# Altium schematic sheet Mechanical.SchDoc(Mechanical)
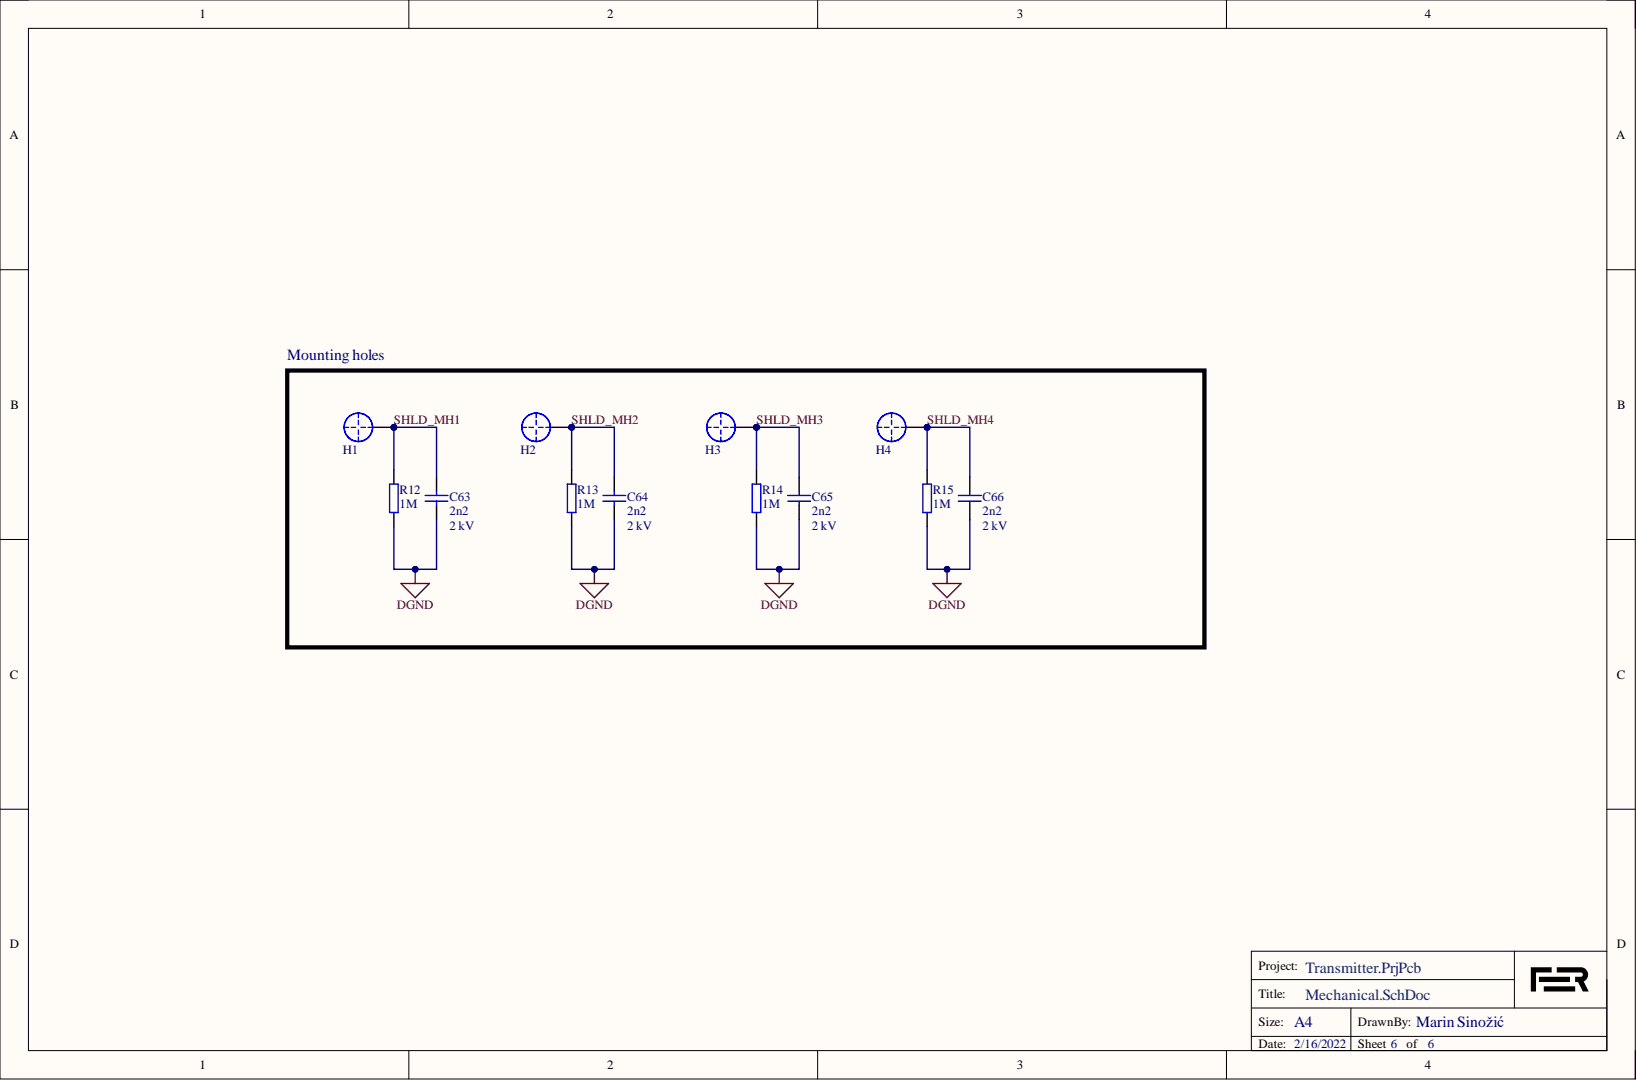
[diagram p0: Mechanical.SchDoc]

## Parts
C63  2n2  Voltage Rating: 2 kV  [0603]
C64  2n2  Voltage Rating: 2 kV  [0603]
C65  2n2  Voltage Rating: 2 kV  [0603]
C66  2n2  Voltage Rating: 2 kV  [0603]
H1  Hole_Mount  [HoleMount]  (value not drawn)  (no BOM)
H2  Hole_Mount  [HoleMount]  (value not drawn)  (no BOM)
H3  Hole_Mount  [HoleMount]  (value not drawn)  (no BOM)
H4  Hole_Mount  [HoleMount]  (value not drawn)  (no BOM)
R12  1M  [0603]
R13  1M  [0603]
R14  1M  [0603]
R15  1M  [0603]

## Nets
DGND: C63.1 C64.1 C65.1 C66.1 R12.1 R13.1 R14.1 R15.1
SHLD_MH1: C63.2 H1.1 R12.2
SHLD_MH2: C64.2 H2.1 R13.2
SHLD_MH3: C65.2 H3.1 R14.2
SHLD_MH4: C66.2 H4.1 R15.2

## Library data of the parts
C63-C66 = C_1206_2.2nF_2kV_X7R_AEC-Q200: CAP CER 0603 2.2NF 16V X7R 10%; Manufacturer: KEMET; Manufacturer Part Number: 399-C0603C222K4RECAUTOCT-ND; Capacitor Case Style: 1206 [3216 Metric]; Database Table Name: Capacitors-Block; RA_SN: C1206-0035; Capacitance Tolerance: ± 10 %; Component Kind: Standard; ComponentLink1Description: Datasheet; ComponentLink1URL: https://hr.mouser.com/datasheet/2/212/KEM_C1076_X7R_HV_AUTO_SMD-1093301.pdf; Dielectric Characteristic: X7R; Library Name: RA.DbLib; Operating Temperature Max: 125 °C; Part Number: C_1206_2.2nF_2kV_X7R_AEC-Q200; Price: 0.16; Qualification: AEC-Q200; Supplier 1: Digi-Key; Supplier 1 ERP Number: 01162; Supplier Part Number 1: 80-C1206C222KGRAUTO; Value: 2n2
H1-H4 = Hole_Mount_M3_Alt: Database Table Name: Mechanical; Library Name: RA.DbLib; Part Number: Hole_Mount_M3_Alt
R12-R15 = R_0603_1M_1%_0W1_100ppm_AEC: RES 1M OHM 1% 1/10W 0603; Manufacturer: Vishay; Manufacturer Part Number: A129724CT-ND; Database Table Name: Resistors; Power Rating: 100 mW; Qualification: AEC-Q200; RA_SN: R0603-0011; Resistor Case Style: 0603 [1608 Metric]; Resistor Element Material: Thick Film; Temperature Coefficient: ± 100 ppm/K; Component Kind: Standard; ComponentLink1Description: Datasheet; ComponentLink1URL: http://www.farnell.com/datasheets/1720486.pdf; Library Name: RA.DbLib; Part Number: R_0603_1M_1%_0W1_100ppm_AEC; Price: 0.10; Supplier 1: Digi-Key; Supplier 1 ERP Number: 00038; Supplier Part Number 1: 1469746; Value: 1M
